# Altium schematic sheet Power_Low.SchDoc
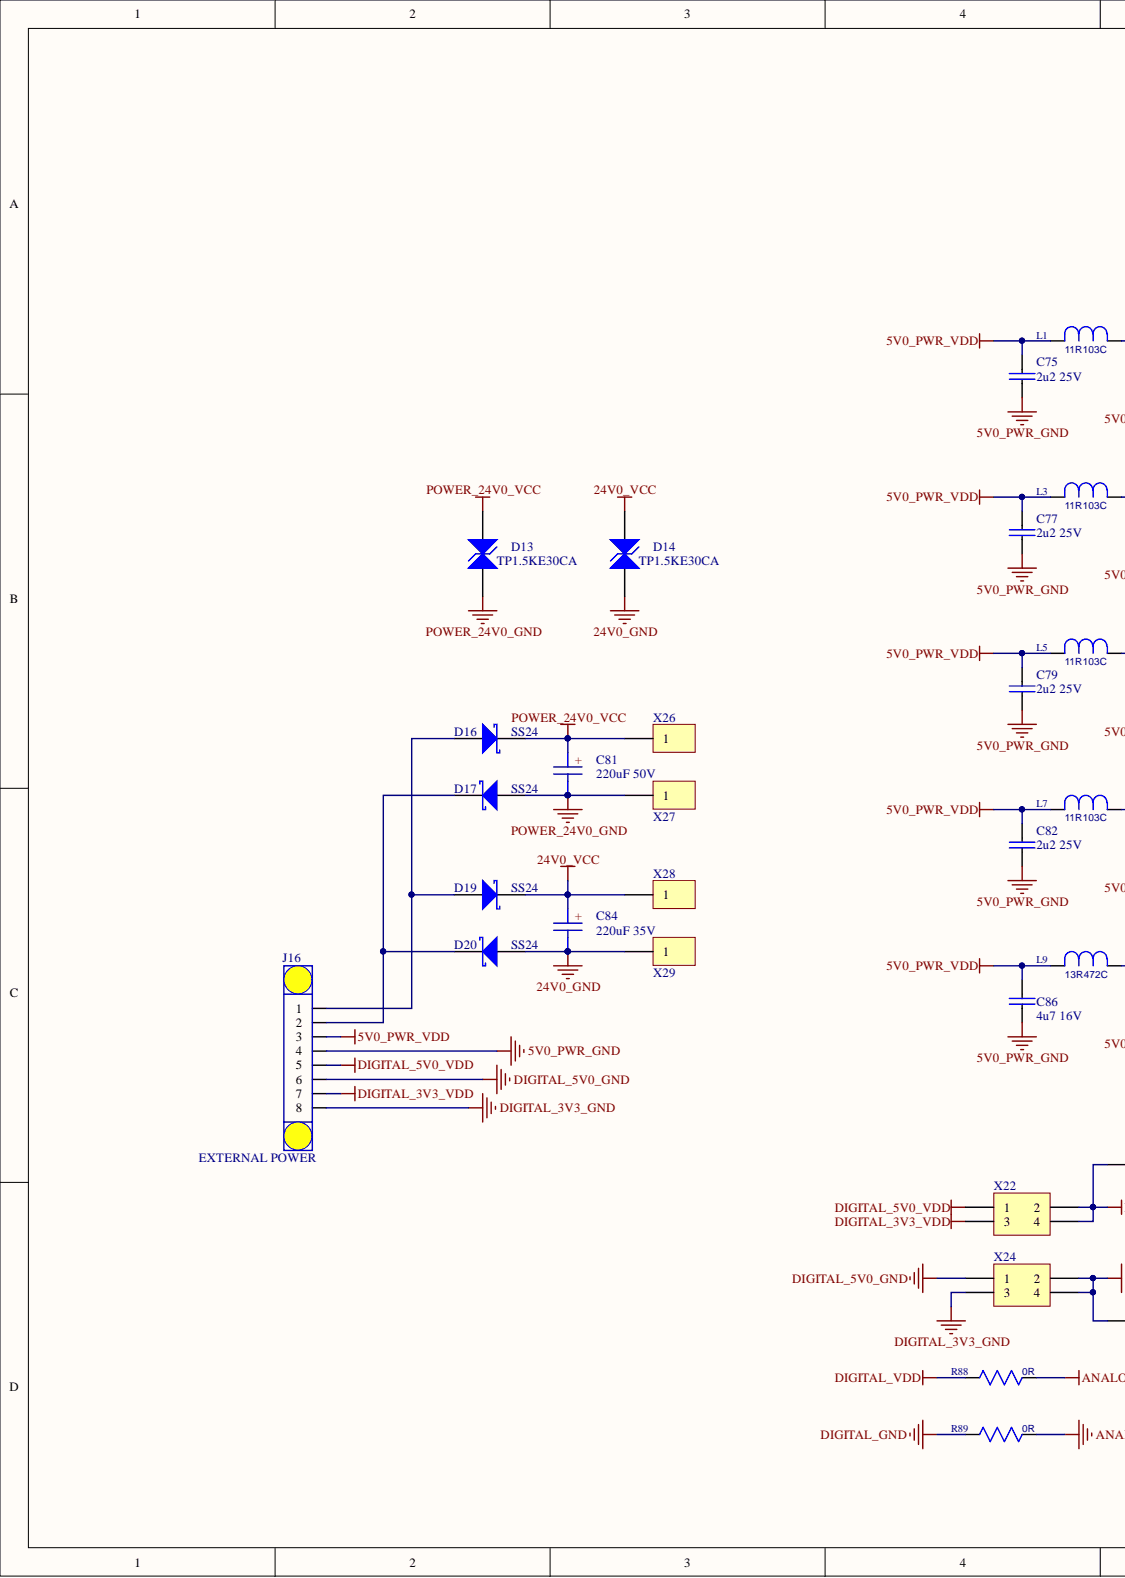
[diagram p0: Power_Low.SchDoc, left]
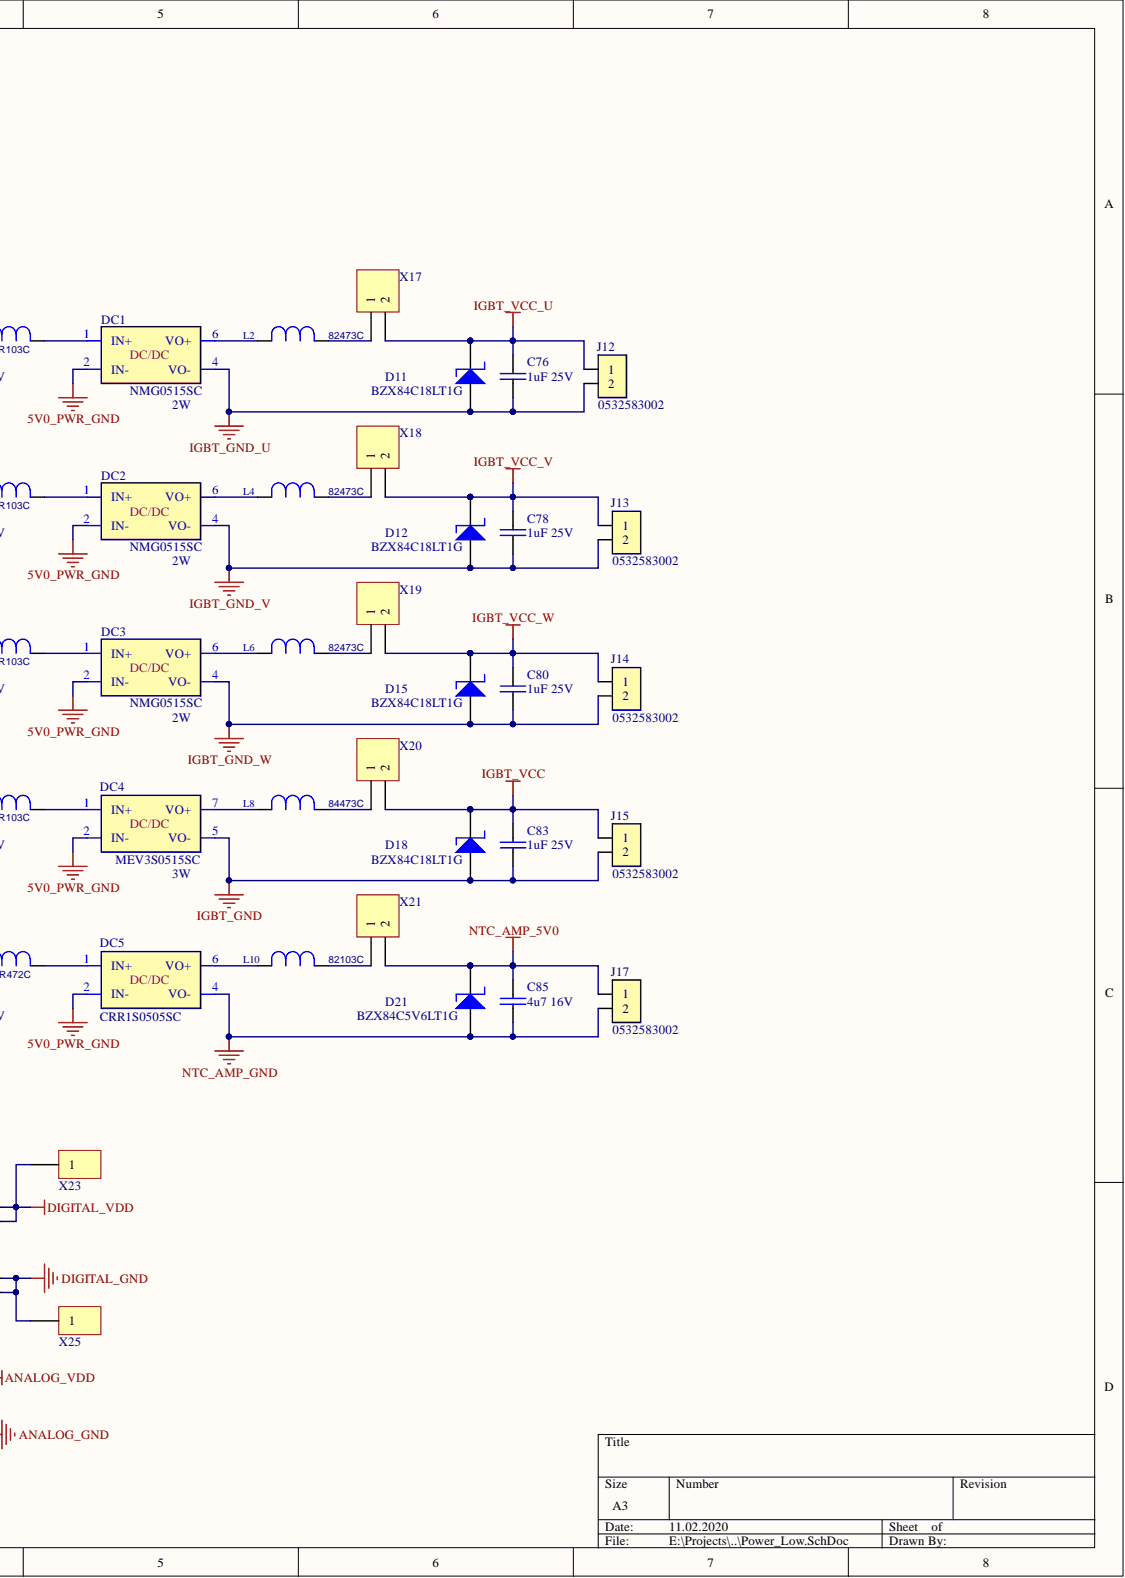
[diagram p1: Power_Low.SchDoc, right]

## Parts
C75  2u2 25V  [C_0805]
C76  1uF 25V  [C_0805]
C77  2u2 25V  [C_0805]
C78  1uF 25V  [C_0805]
C79  2u2 25V  [C_0805]
C80  1uF 25V  [C_0805]
C81  220uF 50V  [C_8x11.5x3.5]
C82  2u2 25V  [C_0805]
C83  1uF 25V  [C_0805]
C84  220uF 35V  [C_8x11.5x3.5]
C85  4u7 16V  [C_0805]
C86  4u7 16V  [C_0805]
D11  BZX84C18LT1G  [SOT23-3]
D12  BZX84C18LT1G  [SOT23-3]
D13  TP1.5KE30CA  [DO-201]
D14  TP1.5KE30CA  [DO-201]
D15  BZX84C18LT1G  [SOT23-3]
D16  SS24  [SMB]
D17  SS24  [SMB]
D18  BZX84C18LT1G  [SOT23-3]
D19  SS24  [SMB]
D20  SS24  [SMB]
D21  BZX84C5V6LT1G  [SOT23-3]
DC1  NMG0515SC  Power: 2W  [SIP-7 4 Leads 2W]
DC2  NMG0515SC  Power: 2W  [SIP-7 4 Leads 2W]
DC3  NMG0515SC  Power: 2W  [SIP-7 4 Leads 2W]
DC4  MEV3S0515SC  Power: 3W  [SIP-7 4 Leads 3W]
DC5  CRR1S0505SC  [SIP-6]
J12  0532583002  [Molex 0532583002]
J13  0532583002  [Molex 0532583002]
J14  0532583002  [Molex 0532583002]
J15  0532583002  [Molex 0532583002]
J16  EXTERNAL POWER  [2EDGRM-5.08-08P]
J17  0532583002  [Molex 0532583002]
L1  11R103C  [1100R]
L2  82473C  [8200C]
L3  11R103C  [1100R]
L4  82473C  [8200C]
L5  11R103C  [1100R]
L6  82473C  [8200C]
L7  11R103C  [1100R]
L8  84473C  [8400]
L9  13R472C  [1300R]
L10  82103C  [8200C]
R88  0R  [R_1206]
R89  0R  [R_1206]
X17  PLS-2  [PLS-2-3D]  (value not drawn)
X18  PLS-2  [PLS-2-3D]  (value not drawn)
X19  PLS-2  [PLS-2-3D]  (value not drawn)
X20  PLS-2  [PLS-2-3D]  (value not drawn)
X21  PLS-2  [PLS-2-3D]  (value not drawn)
X22  PLD-4  [PLD-4-3D]  (value not drawn)
X23  PLS-1  [PLS-1-3D]  (value not drawn)
X24  PLD-4  [PLD-4-3D]  (value not drawn)
X25  PLS-1  [PLS-1-3D]  (value not drawn)
X26  PLS-1  [PLS-1-3D]  (value not drawn)
X27  PLS-1  [PLS-1-3D]  (value not drawn)
X28  PLS-1  [PLS-1-3D]  (value not drawn)
X29  PLS-1  [PLS-1-3D]  (value not drawn)

## Nets
5V0_PWR_GND: C75.2 C77.2 C79.2 C82.2 C86.1 DC1.2(IN-) DC2.2(IN-) DC3.2(IN-) DC4.2(IN-) DC5.2(IN-) J16.4
5V0_PWR_VDD: C75.1 C77.1 C79.1 C82.1 C86.2 J16.3 L1.1 L3.1 L5.1 L7.1 L9.1
24V0_GND: C84.2 D14.1 D20.1 X29.1
24V0_VCC: C84.1 D14.2 D19.2 X28.1
ANALOG_GND: R89.1
ANALOG_VDD: R88.1
DIGITAL_3V3_GND: J16.8 X24.3
DIGITAL_3V3_VDD: J16.7 X22.3
DIGITAL_5V0_GND: J16.6 X24.1
DIGITAL_5V0_VDD: J16.5 X22.1
DIGITAL_GND: R89.2 X24.2 X24.4 X25.1
DIGITAL_VDD: R88.2 X22.2 X22.4 X23.1
IGBT_GND: C83.2 D18.1 DC4.5(VO-) J15.2
IGBT_GND_U: C76.2 D11.1 DC1.4(VO-) J12.2
IGBT_GND_V: C78.2 D12.1 DC2.4(VO-) J13.2
IGBT_GND_W: C80.2 D15.1 DC3.4(VO-) J14.2
IGBT_VCC: C83.1 D18.3 J15.1 X20.2
IGBT_VCC_U: C76.1 D11.3 J12.1 X17.2
IGBT_VCC_V: C78.1 D12.3 J13.1 X18.2
IGBT_VCC_W: C80.1 D15.3 J14.1 X19.2
NTC_AMP_5V0: C85.2 D21.3 J17.1 X21.2
NTC_AMP_GND: C85.1 D21.1 DC5.4(VO-) J17.2
POWER_24V0_GND: C81.2 D13.1 D17.1 X27.1
POWER_24V0_VCC: C81.1 D13.2 D16.2 X26.1
NetD16_1: D16.1 D19.1 J16.1
NetD17_2: D17.2 D20.2 J16.2
NetDC1_1: DC1.1(IN+) L1.2
NetDC1_6: DC1.6(VO+) L2.1
NetDC2_1: DC2.1(IN+) L3.2
NetDC2_6: DC2.6(VO+) L4.1
NetDC3_1: DC3.1(IN+) L5.2
NetDC3_6: DC3.6(VO+) L6.1
NetDC4_1: DC4.1(IN+) L7.2
NetDC4_7: DC4.7(VO+) L8.1
NetDC5_1: DC5.1(IN+) L9.2
NetDC5_6: DC5.6(VO+) L10.1
NetL2_2: L2.2 X17.1
NetL4_2: L4.2 X18.1
NetL6_2: L6.2 X19.1
NetL8_2: L8.2 X20.1
NetL10_2: L10.2 X21.1

## Library data of the parts
C75-C80 C82 C83 C85 C86 = Cap NP: CERAMIC CAPACITOR; Component Kind: Standard; Library Name: Capacitors.SchLib
  C75 C77 C79 C82: Value: 2u2 25V
  C76 C78 C80 C83: Value: 1uF 25V
  C85 C86: Value: 4u7 16V
C81 C84 = WL_SIZE_8x11.5x3.5: Aluminum Electrolytic Capacitors; Component Kind: Standard; Library Name: Capacitors.SchLib
  C81: Value: 220uF 50V
  C84: Value: 220uF 35V
D11 D12 D15 D18 D21 = BZX84CxxxLT1G: Zener Voltage Regulators 250mW SOT-23; Component Kind: Standard; Library Name: Diode.SchLib
  D11 D12 D15 D18: Value: BZX84C18LT1G
  D21: Value: BZX84C5V6LT1G
D13 D14 = 1.5KEXXXCA: Transient Voltage Suppression Diodes; Component Kind: Standard; Library Name: Diode.SchLib; Value: TP1.5KE30CA
D16 D17 D19 D20 = D Schottky: Schottky Diode; Code_JEDEC: DO-214-AA; Component Kind: Standard; LatestRevisionDate: 17-Jul-2002; LatestRevisionNote: Re-released for DXP Platform.; Library Name: Diode.SchLib; PackageDescription: DO-214-AA/SMB; 2 C-Bend Leads; Body 5.3 x 3.6 mm, inc. leads (LxW); PackageReference: SMB; Published: 8-Jun-2000; Signal Integrity: D Schottky; Simulation: SKYDIODE; Publisher: Altium Limited
DC1-DC3 = NMG0515SC: Isolated 2W Single Output 15VDC Input 5VDC; Component Kind: Standard; Library Name: Power.SchLib; Value: NMG0515SC
DC4 = MEV3S0515SC: Isolated 3W Single Output 15VDC Input 5VDC; Component Kind: Standard; Library Name: Power.SchLib; Value: MEV3S0515SC
DC5 = CRR1S0505SC: Isolated 1W Single Output DC/DC Converter; Component Kind: Standard; Library Name: Power.SchLib; Value: CRR1S0505SC
J12-J15 J17 = Molex 0532583002: CONN HEADER VERT 2POS 3.5MM; Component Kind: Standard; Library Name: Connectors.SchLib; Value: 0532583002
J16 = 2EDGRM-5.08-08P: DEGSON Connector 5.08 pitch; Component Kind: Standard; Library Name: Connectors.SchLib; Text: EXTERNAL POWER
L1 L3 L5 L7 = 1100R Series: Inductor; Component Kind: Standard; Library Name: Inductor.SchLib; Value: 11R103C
L2 L4 L6 L10 = 8200C Series: Inductor; Component Kind: Standard; Library Name: Inductor.SchLib
  L2 L4 L6: Value: 82473C
  L10: Value: 82103C
L8 = 8400 Series: Inductor; Component Kind: Standard; Library Name: Inductor.SchLib; Value: 84473C
L9 = 1300R Series: Inductor; Component Kind: Standard; Library Name: Inductor.SchLib; Value: 13R472C
R88 R89 = R1206: Resistor; Component Kind: Standard; Library Name: Resistors.SchLib; Value: 0R
X17-X21 = PLS-2: Header, 2-Pin; Component Kind: Standard; LatestRevisionDate: 17-Jul-2002; LatestRevisionNote: Re-released for DXP Platform.; Library Name: Connectors.SchLib; Publisher: Altium Limited
X22 X24 = PLD-4: Header, 2-Pin, Dual row; Component Kind: Standard; LatestRevisionDate: 17-Jul-2002; LatestRevisionNote: Re-released for DXP Platform.; Library Name: Connectors.SchLib; Publisher: Altium Limited
X23 X25-X29 = PLS-1: Header, 1-Pin; Component Kind: Standard; LatestRevisionDate: 17-Jul-2002; LatestRevisionNote: Re-released for DXP Platform.; Library Name: Connectors.SchLib; Publisher: Altium Limited
